# Altium schematic sheet 02 PX4-MCU-V1.0.SchDoc("02 PX4-MCU-V1.0")
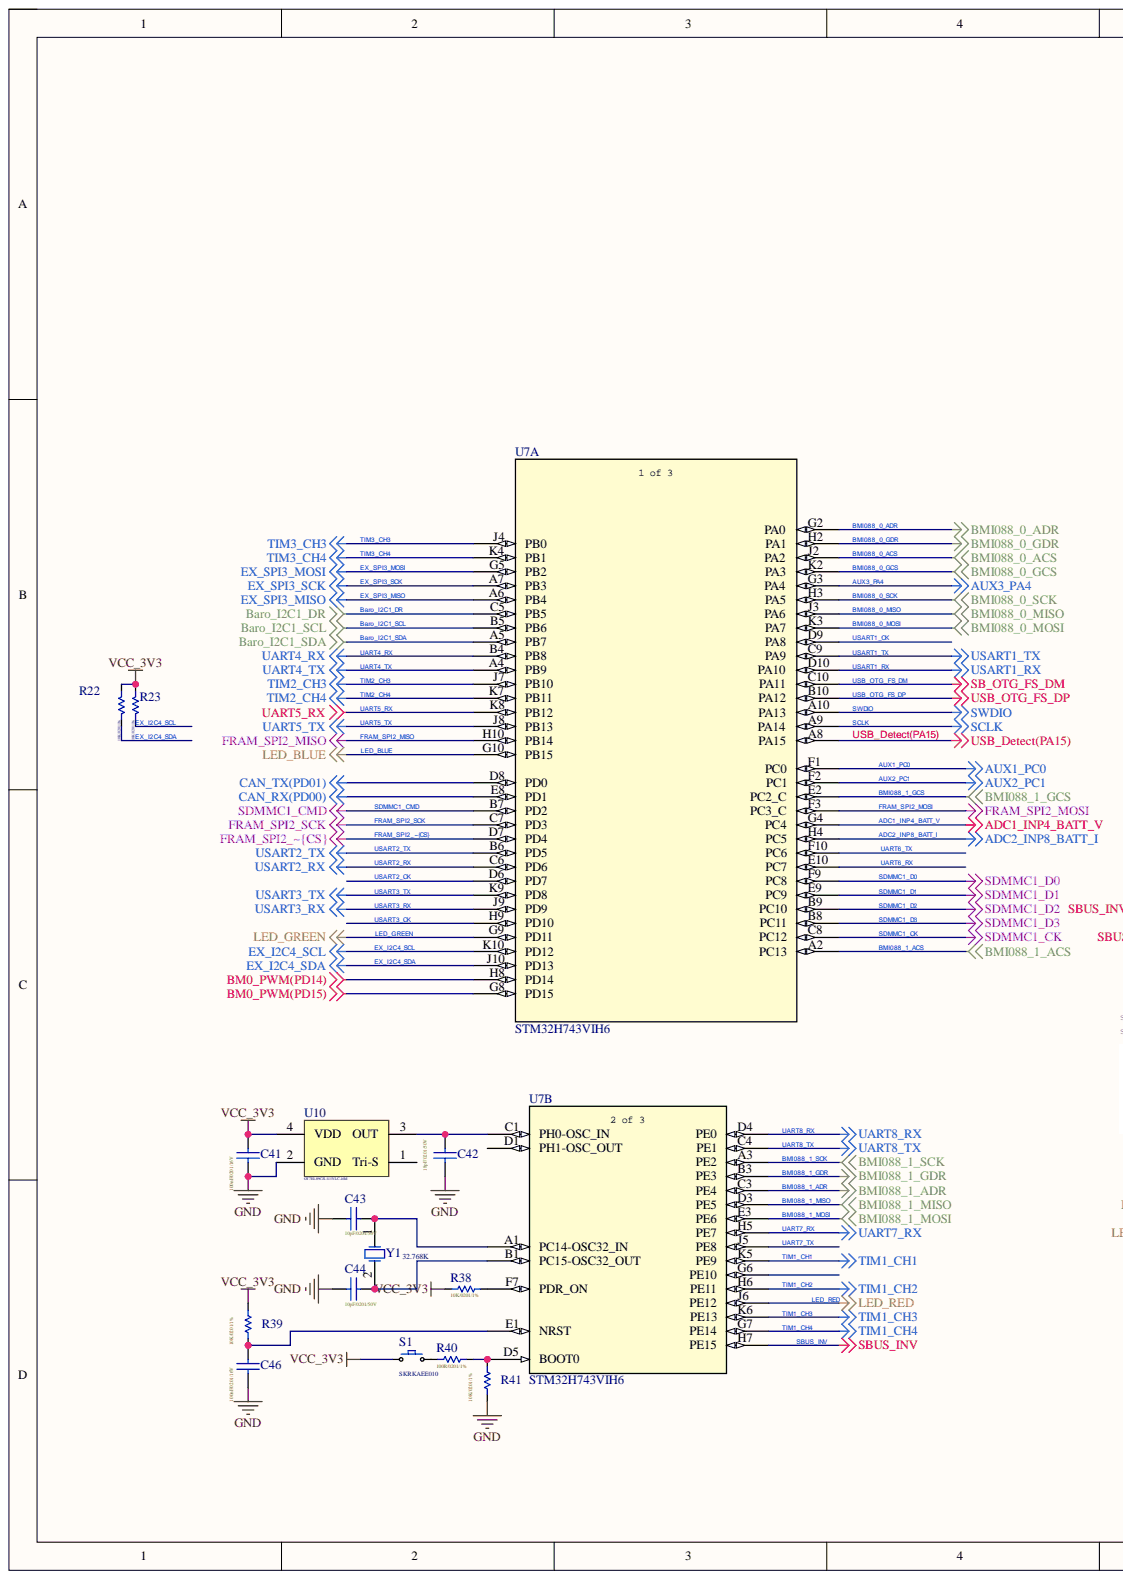
[diagram p0: 02 PX4-MCU-V1.0.SchDoc, left]
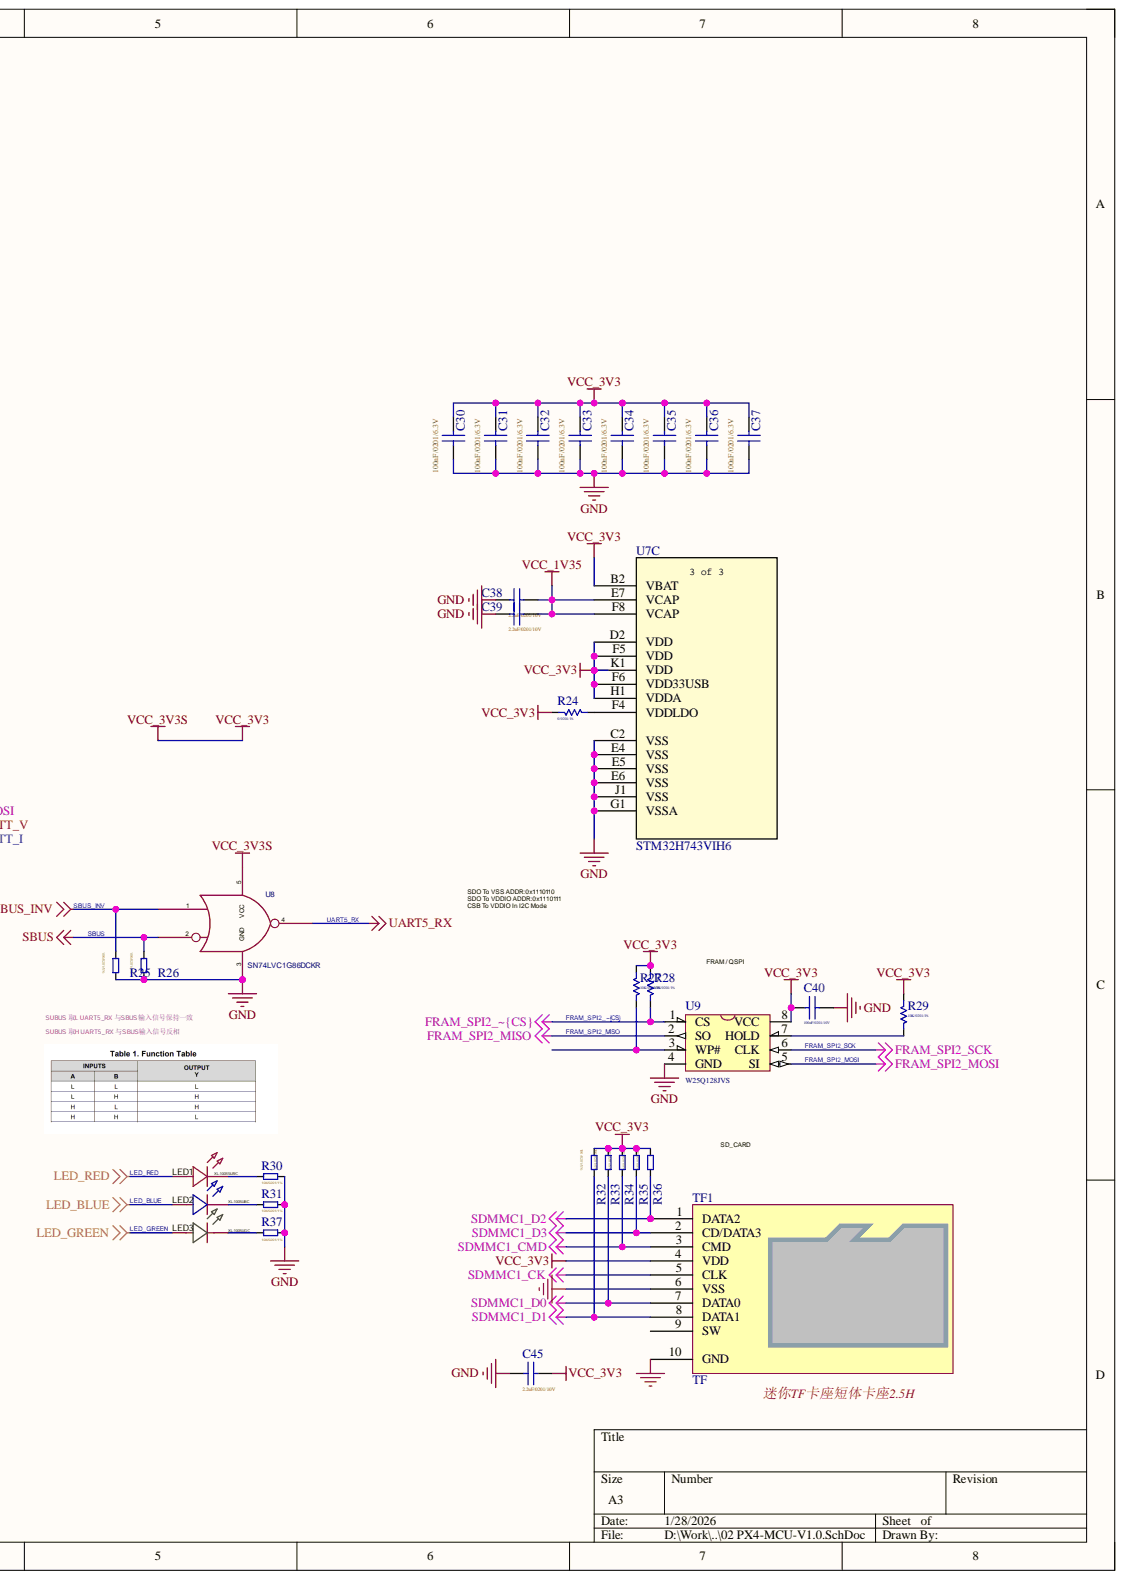
[diagram p1: 02 PX4-MCU-V1.0.SchDoc, right]

## Parts
C30  100nF/0201/6.3V  [C0201]
C31  100nF/0201/6.3V  [C0201]
C32  100nF/0201/6.3V  [C0201]
C33  100nF/0201/6.3V  [C0201]
C34  100nF/0201/6.3V  [C0201]
C35  100nF/0201/6.3V  [C0201]
C36  100nF/0201/6.3V  [C0201]
C37  100nF/0201/6.3V  [C0201]
C38  2.2uF/0201/10V  [C0201]
C39  2.2uF/0201/10V  [C0201]
C40  100nF/0201/10V  [C0201]
C41  100nF/0201/16V  [C0201]
C42  18pF/0201/50V  [C0201]
C43  10pF/0201/50V  [C0201]
C44  10pF/0201/50V  [C0201]
C45  2.2uF/0201/10V  [C0201]
C46  100nF/0201/16V  [C0201]
LED1  XL-1005SURC  [LED0402-RD_RED]
LED2  XL-1005UBC  [LED0402-RD_BLUE]
LED3  XL-1005UGC  [LED0402-RD_GREEN]
R22  10K/0201/1%  [R0201]
R23  10K/0201/1%  [R0201]
R24  0/0201/1%  [R0201]
R25  10K/0201/5%  [R0201]
R26  10K/0201/5%  [R0201]
R27  10K/0201/1%  [R0201]
R28  10K/0201/1%  [R0201]
R29  10K/0201/1%  [R0201]
R30  10K/0201/1%  [R0201]
R31  10K/0201/1%  [R0201]
R32  10K/0201/5%  [R0201]
R33  10K/0201/5%  [R0201]
R34  10K/0201/5%  [R0201]
R35  10K/0201/5%  [R0201]
R36  10K/0201/5%  [R0201]
R37  10K/0201/1%  [R0201]
R38  10K/0201/1%  [R0201]
R39  10K/0201/1%  [R0201]
R40  100R/0201/1%  [R0201]
R41  10K/0201/1%  [R0201]
S1  SKRKAEE010  [ButtonTACT_3x4x2_180-不带柱]
TF1  TF  [TF-SOCKET - short]
U7  STM32H743VIH6  [TFBGA100]  (parts A,B,C)
U8  value SN74LVC1G86DCKR  ki_keywords: SN74LVC1G86DCKR  [SC-70-5_L2.1-W1.3-P0.65-LS2.1-BR]  (hidden comment: NxtPX4_Symbol_SN74LVC1G86DCKR)
U9  W25Q128JVS  [SOIC-8 208MIL WIDE]
U10  OT7EL89CJI-111YLC-16M  [OSC-SMD_4P-L2.0-W1.6-BL]
Y1  32.768K  [3215]

## Nets
BM0_PWM(PD14): U7.H8(PD14)
BM0_PWM(PD15): U7.G8(PD15)
CAN_RX(PD00): U7.E8(PD1)
CAN_TX(PD01): U7.D8(PD0)
GND: C30.1 C31.1 C32.1 C33.1 C34.1 C35.1 C36.1 C37.1 C38.1 C39.2 C40.2 C41.1 C42.2 C43.2 C44.2 C45.2 C46.1 R25.2 R26.2 R30.2 R31.2 R37.2 R41.1 TF1.6(VSS) TF1.10(GND) U7.C2(VSS) U7.E4(VSS) U7.E5(VSS) U7.E6(VSS) U7.G1(VSSA) U7.J1(VSS) U8.3(GND) U9.4(GND) U10.2(GND)
VCC_1V35: C38.2 C39.1 U7.E7(VCAP) U7.F8(VCAP)
VCC_3V3: C30.2 C31.2 C32.2 C33.2 C34.2 C35.2 C36.2 C37.2 C40.1 C41.2 C45.1 R22.1 R23.1 R24.1 R27.1 R28.1 R29.1 R32.1 R33.1 R34.1 R35.1 R36.1 R38.2 R39.2 S1.1 TF1.4(VDD) U7.B2(VBAT) U7.D2(VDD) U7.F5(VDD) U7.F6(VDD33USB) U7.H1(VDDA) U7.K1(VDD) U8.5(VCC) U9.8(VCC) U10.4(VDD)
ADC1_INP4_BATT_V: U7.G4(PC4)
ADC2_INP8_BATT_I: U7.H4(PC5)
AUX1_PC0: U7.F1(PC0)
AUX2_PC1: U7.F2(PC1)
AUX3_PA4: U7.G3(PA4)
Baro_I2C1_DR: U7.C5(PB5)
Baro_I2C1_SCL: U7.B5(PB6)
Baro_I2C1_SDA: U7.A5(PB7)
BMI088_0_ACS: U7.J2(PA2)
BMI088_0_ADR: U7.G2(PA0)
BMI088_0_GCS: U7.K2(PA3)
BMI088_0_GDR: U7.H2(PA1)
BMI088_0_MISO: U7.J3(PA6)
BMI088_0_MOSI: U7.K3(PA7)
BMI088_0_SCK: U7.H3(PA5)
BMI088_1_ACS: U7.A2(PC13)
BMI088_1_ADR: U7.C3(PE4)
BMI088_1_GCS: U7.E2(PC2_C)
BMI088_1_GDR: U7.B3(PE3)
BMI088_1_MISO: U7.D3(PE5)
BMI088_1_MOSI: U7.E3(PE6)
BMI088_1_SCK: U7.A3(PE2)
EX_I2C4_SCL: R23.2 U7.K10(PD12)
EX_I2C4_SDA: R22.2 U7.J10(PD13)
EX_SPI3_MISO: U7.A6(PB4)
EX_SPI3_MOSI: U7.G5(PB2)
EX_SPI3_SCK: U7.A7(PB3)
FRAM_SPI2_MISO: U7.H10(PB14) U9.2(SO)
FRAM_SPI2_MOSI: U7.F3(PC3_C) U9.5(SI)
FRAM_SPI2_SCK: U7.C7(PD3) U9.6(CLK)
FRAM_SPI2_~{CS}: R28.2 U7.D7(PD4) U9.1(CS)
LED_BLUE: LED2.2 U7.G10(PB15)
LED_GREEN: LED3.2 U7.G9(PD11)
LED_RED: LED1.2 U7.J6(PE12)
SBUS: R26.1 U8.2
SBUS_INV: R25.1 U7.H7(PE15) U8.1
SCLK: U7.A9(PA14)
SDMMC1_CK: TF1.5(CLK) U7.C8(PC12)
SDMMC1_CMD: R34.2 TF1.3(CMD) U7.B7(PD2)
SDMMC1_D0: R33.2 TF1.7(DATA0) U7.F9(PC8)
SDMMC1_D1: R32.2 TF1.8(DATA1) U7.E9(PC9)
SDMMC1_D2: R36.2 TF1.1(DATA2) U7.B9(PC10)
SDMMC1_D3: R35.2 TF1.2(CD/DATA3) U7.B8(PC11)
SWDIO: U7.A10(PA13)
TIM1_CH1: U7.K5(PE9)
TIM1_CH2: U7.H6(PE11)
TIM1_CH3: U7.K6(PE13)
TIM1_CH4: U7.G7(PE14)
TIM2_CH3: U7.J7(PB10)
TIM2_CH4: U7.K7(PB11)
TIM3_CH3: U7.J4(PB0)
TIM3_CH4: U7.K4(PB1)
UART4_RX: U7.B4(PB8)
UART4_TX: U7.A4(PB9)
UART5_RX: U7.K8(PB12) U8.4
UART5_TX: U7.J8(PB13)
UART6_RX: U7.E10(PC7)
UART6_TX: U7.F10(PC6)
UART7_RX: U7.H5(PE7)
UART7_TX: U7.J5(PE8)
UART8_RX: U7.D4(PE0)
UART8_TX: U7.C4(PE1)
USART1_CK: U7.D9(PA8)
USART1_RX: U7.D10(PA10)
USART1_TX: U7.C9(PA9)
USART2_CK: U7.D6(PD7)
USART2_RX: U7.C6(PD6)
USART2_TX: U7.B6(PD5)
USART3_CK: U7.H9(PD10)
USART3_RX: U7.J9(PD9)
USART3_TX: U7.K9(PD8)
USB_Detect(PA15): U7.A8(PA15)
USB_OTG_FS_DM: U7.C10(PA11)
USB_OTG_FS_DP: U7.B10(PA12)
NetC42_1: C42.1 U7.C1(PH0-OSC_IN) U10.3(OUT)
NetC43_1: C43.1 U7.A1(PC14-OSC32_IN) Y1.1
NetC44_1: C44.1 U7.B1(PC15-OSC32_OUT) Y1.2
NetC46_2: C46.2 R39.1 U7.E1(NRST)
NetLED1_1: LED1.1 R30.1
NetLED2_1: LED2.1 R31.1
NetLED3_1: LED3.1 R37.1
NetR24_2: R24.2 U7.F4(VDDLDO)
NetR27_2: R27.2 U9.3(WP#)
NetR29_2: R29.2 U9.7(HOLD)
NetR38_1: R38.1 U7.F7(PDR_ON)
NetR40_1: R40.1 R41.2 U7.D5(BOOT0)
NetR40_2: R40.2 S1.2

Not connected: TF1 9(SW); U7 D1(PH1-OSC_OUT) G6(PE10); U10 1(Tri-S)

## Library data of the parts
C30-C37 = Cap: Value: 100nF/0402/50V; Component Kind: Standard; Description: 100nF/0201/6.3V; LatestRevisionDate: 17-Jul-2002; LatestRevisionNote: Re-released for DXP Platform.; Library Name: Miscellaneous Devices.IntLib; PackageDescription: Radial Cap, Thru-Hole; 2 Leads; 0.3 in Pin Spacing; PackageReference: RAD-0.3; Published: 8-Jun-2000; Publisher: Altium Limited
C38 C39 C41 C45 C46 = Cap: Value: 1uF/0603/50V; Component Kind: Standard; LatestRevisionDate: 17-Jul-2002; LatestRevisionNote: Re-released for DXP Platform.; Library Name: Miscellaneous Devices.IntLib; PackageDescription: Radial Cap, Thru-Hole; 2 Leads; 0.3 in Pin Spacing; PackageReference: RAD-0.3; Published: 8-Jun-2000; Signal Integrity: Cap; Simulation: CAP; Publisher: Altium Limited
  C38 C39 C45: Description: 2.2uF/0201/10V
  C41 C46: Description: 100nF/0201/16V
C40 = Cap: Component Kind: Standard; Description: 100nF/0201/10V; LatestRevisionDate: 17-Jul-2002; LatestRevisionNote: Re-released for DXP Platform.; Library Name: Miscellaneous Devices.IntLib; PackageDescription: Radial Cap, Thru-Hole; 2 Leads; 0.3 in Pin Spacing; PackageReference: RAD-0.3; Published: 8-Jun-2000; Signal Integrity: Cap; Simulation: CAP; Publisher: Altium Limited
C42-C44 = Cap: Value: 18pF/0603/50V; Component Kind: Standard; LatestRevisionDate: 17-Jul-2002; LatestRevisionNote: Re-released for DXP Platform.; Library Name: Miscellaneous Devices.IntLib; PackageDescription: Radial Cap, Thru-Hole; 2 Leads; 0.3 in Pin Spacing; PackageReference: RAD-0.3; Published: 8-Jun-2000; Publisher: Altium Limited
  C42: Description: 18pF/0201/50V
  C43 C44: Description: 10pF/0201/50V
LED1 = XL-1005SURC: Manufacturer: XINGLIGHT(成兴光); Add into BOM: yes; Device: XL-1005UOC; Dominant Wavelength: 600nm; Emitted Color: 橙色; Forward Current: 25mA; Lens Color: 无色透明透镜; Mounting Sytle: 正贴; Peak Wavelength: 610nm; Power Dissipation: 55mW; Viewing Angle: 120°; Color Temperature: -; Component Kind: Standard; Convert to PCB: yes; Datasheet: https://atta.szlcsc.com/upload/public/pdf/source/20221102/20343CDAB7C90B2E3EF3073DFAD545BA.pdf; JLCPCB Part Class: Extended Part; LCSC Part Name: XL-1005UOC; Library Name: dm-sch_library.SchLib; Manufacturer Part: XL-1005UOC; Operating Temperature: -30℃~+85℃; Supplier: LCSC; Supplier Footprint: 0402; Supplier Part: C965791; Symbol: XL-1005UOC
LED2 = XL-1005UBC: Manufacturer: XINGLIGHT(成兴光); Add into BOM: yes; Device: XL-1005UOC; Dominant Wavelength: 600nm; Emitted Color: 橙色; Forward Current: 25mA; Lens Color: 无色透明透镜; Mounting Sytle: 正贴; Peak Wavelength: 610nm; Power Dissipation: 55mW; Viewing Angle: 120°; Color Temperature: -; Component Kind: Standard; Convert to PCB: yes; Datasheet: https://atta.szlcsc.com/upload/public/pdf/source/20221102/20343CDAB7C90B2E3EF3073DFAD545BA.pdf; JLCPCB Part Class: Extended Part; LCSC Part Name: XL-1005UOC; Library Name: dm-sch_library.SchLib; Manufacturer Part: XL-1005UOC; Operating Temperature: -30℃~+85℃; Supplier: LCSC; Supplier Footprint: 0402; Supplier Part: C965791; Symbol: XL-1005UOC
LED3 = XL-1005UGC: Manufacturer: XINGLIGHT(成兴光); Add into BOM: yes; Device: XL-1005UOC; Dominant Wavelength: 600nm; Emitted Color: 橙色; Forward Current: 25mA; Lens Color: 无色透明透镜; Mounting Sytle: 正贴; Peak Wavelength: 610nm; Power Dissipation: 55mW; Viewing Angle: 120°; Color Temperature: -; Component Kind: Standard; Convert to PCB: yes; Datasheet: https://atta.szlcsc.com/upload/public/pdf/source/20221102/20343CDAB7C90B2E3EF3073DFAD545BA.pdf; JLCPCB Part Class: Extended Part; LCSC Part Name: XL-1005UOC; Library Name: dm-sch_library.SchLib; Manufacturer Part: XL-1005UOC; Operating Temperature: -30℃~+85℃; Supplier: LCSC; Supplier Footprint: 0402; Supplier Part: C965791; Symbol: XL-1005UOC
R22 R23 R27-R29 = Res Semi: Component Kind: Standard; Description: 10K/0201/1%; LatestRevisionDate: 17-Jul-2002; LatestRevisionNote: Re-released for DXP Platform.; Library Name: Miscellaneous Devices.IntLib; PackageDescription: Axial Device, Thru-Hole; 2 Leads; 0.5 in Pin Spacing; PackageReference: AXIAL-0.5; Published: 8-Jun-2000; Publisher: Altium Limited
R24 = Res Semi: 10K/0201/1%; Component Kind: Standard; LatestRevisionDate: 17-Jul-2002; LatestRevisionNote: Re-released for DXP Platform.; Library Name: Miscellaneous Devices.IntLib; PackageDescription: Axial Device, Thru-Hole; 2 Leads; 0.5 in Pin Spacing; PackageReference: AXIAL-0.5; Published: 8-Jun-2000; Publisher: Altium Limited
R25 R26 R32-R36 = R: Component Kind: Standard; Description: 10K/0201/5%; Library Name: ROBO_V01_2015.SchLib
R30 R31 R37 = R: 10K/0201/5%; Component Kind: Standard; Library Name: ROBO_V01_2015.SchLib
R38-R41 = Res Semi: Value: 10K/0603/5%; Component Kind: Standard; LatestRevisionDate: 17-Jul-2002; LatestRevisionNote: Re-released for DXP Platform.; Library Name: Miscellaneous Devices.IntLib; PackageDescription: Axial Device, Thru-Hole; 2 Leads; 0.5 in Pin Spacing; PackageReference: AXIAL-0.5; Published: 8-Jun-2000; Publisher: Altium Limited
  R38 R39 R41: Description: 10K/0201/1%
  R40: Description: 100R/0201/1%
S1 = KEY: SKRPADE010; Component Kind: Standard; Library Name: ROBO_V01_2015-DESKTOP-643COGG.SchLib
TF1 = TF卡座: 8脚自弹; Component Kind: Standard; Library Name: dm-sch_library.SchLib
U7 = STM32H743VIH6: High-performance and DSP with DP-FPU, Arm Cortex-M7 MCU with 2MBytes of Flash memory, 1MB RAM, 480 MHz CPU, Art Accelerator, L1 cache, external memory interface, large set of peripherals; Manufacturer_Name: STMicroelectronics; Component Kind: Standard; Library Name: dm-sch_library.SchLib; Manufacturer_Part_Number: STM32H743VIH6; RefDes: RefDes; Type: Type; Copyright: Copyright (C) 2025 Ultra Librarian. All rights reserved.
U8 = NxtPX4_Symbol_SN74LVC1G86DCKR: ki_fp_filters: DCK5 DCK5-M DCK5-L; Component Kind: Standard; Device: NxtPX4_Symbol_SN74LVC1G86DCKR; Symbol: NxtPX4_Symbol_SN74LVC1G86DCKR; UniqueId: a4a6b0395ae03088; UserDocLink: SN74LVC1G86DCKR
U9 = W25X16: Component Kind: Standard; Description: W25Q128JVS; Library Name: ROBO_V01_2015-DESKTOP-643COGG.SchLib
Y1 = XTAL-2: Component Kind: Standard; Library Name: Schlib1.SchLib
U10 = OT7EL89CJI-111YLC-16M: Component Kind: Standard; Description: OT7EL89CJI-111YLC-16M; Library Name: dm-sch_library.SchLib
